# Altium schematic sheet MCU.SchDoc(MCU)
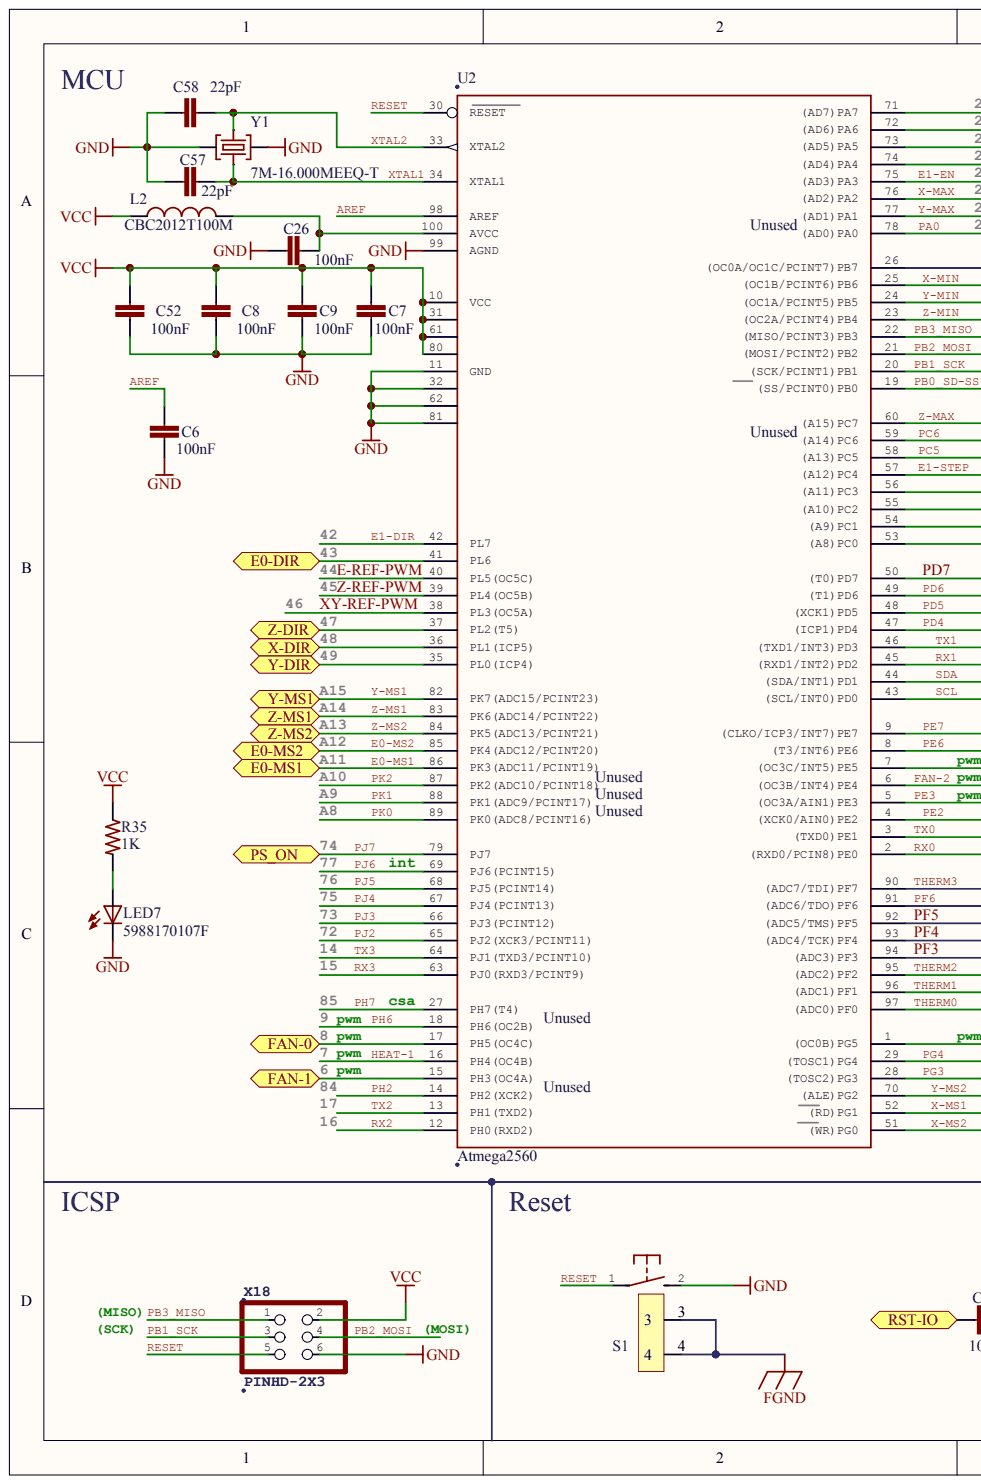
[diagram p0: MCU.SchDoc, left]
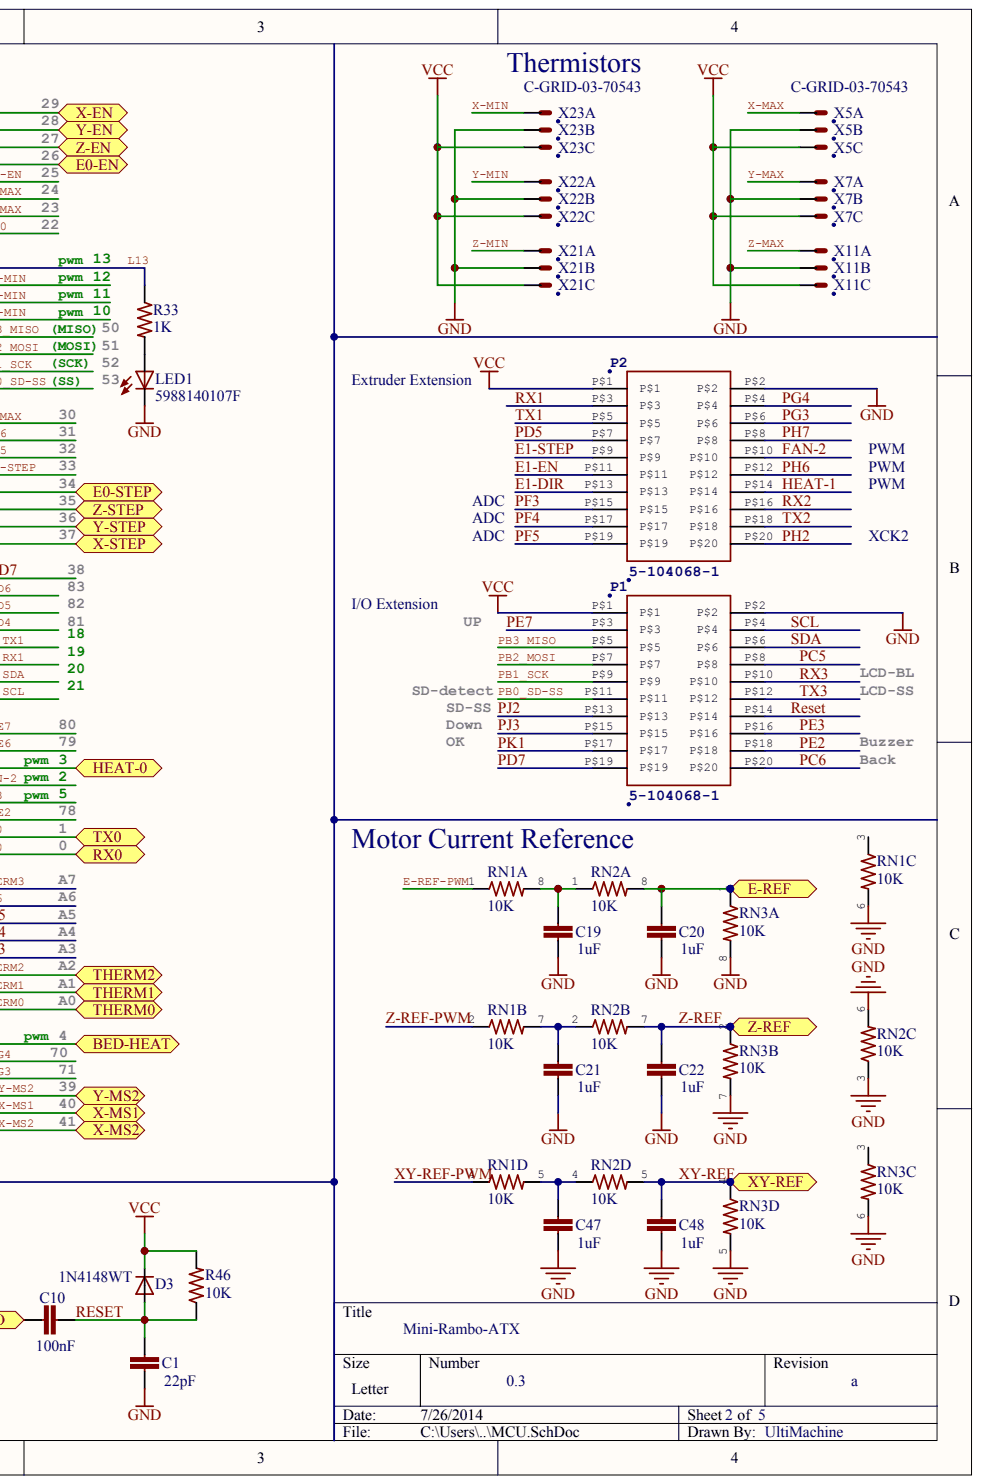
[diagram p1: MCU.SchDoc, right]

## Parts
C1  22pF
C6  100nF
C7  100nF
C8  100nF
C9  100nF
C10  100nF
C19  1uF
C20  1uF
C21  1uF
C22  1uF
C26  100nF
C47  1uF
C48  1uF
C52  100nF
C57  22pF
C58  22pF
D3  1N4148WT
L2  CBC2012T100M
LED1  5988140107F
LED7  5988170107F
P1  5-104068-1
P2  5-104068-1
R33  1K
R35  1K
R46  10K
RN1  10K  (parts A,B,C,D)
RN2  10K  (parts A,B,C,D)
RN3  10K  (parts A,B,C,D)
S1  1571610-2  (value not drawn)
U2  Atmega2560
X5  C-GRID-03-70543  (parts A,B,C)
X7  C-GRID-03-70543  (parts A,B,C)
X11  C-GRID-03-70543  (parts A,B,C)
X18  PINHD-2X3
X21  C-GRID-03-70543  (parts A,B,C)
X22  C-GRID-03-70543  (parts A,B,C)
X23  C-GRID-03-70543  (parts A,B,C)
Y1  7M-16.000MEEQ-T

## Ports
BED-HEAT, E0-DIR, E0-EN, E0-MS1, E0-MS2, E0-STEP, E-REF, FAN-0, FAN-1, HEAT-0, PS_ON, RST-IO, RX0, THERM0, THERM1, THERM2, TX0, X-DIR, X-EN, X-MS1, X-MS2, X-STEP, XY-REF, Y-DIR, Y-EN, Y-MS1, Y-MS2, Y-STEP, Z-DIR, Z-EN, Z-MS1, Z-MS2, Z-REF, Z-STEP

## Nets
FGND: S1.3 S1.4
GND: C1.2 C6.2 C7.2 C8.2 C9.2 C19.2 C20.2 C21.2 C22.2 C26.2 C47.2 C48.2 C52.2 C57.2 C58.2 LED1.C LED7.C P1.P$2 P2.P$2 RN1.6 RN2.3 RN2.6 RN3.5 RN3.6 RN3.7 RN3.8 S1.2 U2.11(GND) U2.32 U2.62 U2.81 U2.99(AGND) X5.2 X7.2 X11.2 X18.6 X21.2 X22.2 X23.2 Y1.2 Y1.4
VCC: C7.1 C8.1 C9.1 C52.1 D3.C L2.1 P1.P$1 P2.P$1 R35.1 R46.2 U2.10(VCC) U2.31 U2.61 U2.80 X5.3 X7.3 X11.3 X18.2 X21.3 X22.3 X23.3
AREF: C6.1 U2.98(AREF)
BED-HEAT: U2.1((OC0B)PG5) ; port BED-HEAT
E0-DIR: U2.41(PL6) ; port E0-DIR
E0-EN: U2.74((AD4)PA4) ; port E0-EN
E0-MS1: U2.86(PK3(ADC11/PCINT19)) ; port E0-MS1
E0-MS2: U2.85(PK4(ADC12/PCINT20)) ; port E0-MS2
E0-STEP: U2.56((A11)PC3) ; port E0-STEP
E1-DIR: P2.P$13 U2.42(PL7)
E1-EN: P2.P$11 U2.75((AD3)PA3)
E1-STEP: P2.P$9 U2.57((A12)PC4)
E-REF: C20.1 RN2.8 RN3.1 ; port E-REF
E-REF-PWM: RN1.1 U2.40(PL5(OC5C))
FAN-0: U2.17(PH5(OC4C)) ; port FAN-0
FAN-1: U2.15(PH3(OC4A)) ; port FAN-1
FAN-2: P2.P$10 U2.6((OC3B/INT4)PE4)
HEAT-0: U2.7((OC3C/INT5)PE5) ; port HEAT-0
HEAT-1: P2.P$14 U2.16(PH4(OC4B))
L13: R33.1 U2.26((OC0A/OC1C/PCINT7)PB7)
PA0: U2.78((AD0)PA0)
PB0_SD-SS: P1.P$11 U2.19((SS/PCINT0)PB0)
PB1_SCK: P1.P$9 U2.20((SCK/PCINT1)PB1) X18.3
PB2_MOSI: P1.P$7 U2.21((MOSI/PCINT2)PB2) X18.4
PB3_MISO: P1.P$5 U2.22((MISO/PCINT3)PB3) X18.1
PC5: P1.P$8 U2.58((A13)PC5)
PC6: P1.P$20 U2.59((A14)PC6)
PD4: U2.47((ICP1)PD4)
PD5: P2.P$7 U2.48((XCK1)PD5)
PD6: U2.49((T1)PD6)
PD7: P1.P$19 U2.50((T0)PD7)
PE2: P1.P$18 U2.4((XCK0/AIN0)PE2)
PE3: P1.P$16 U2.5((OC3A/AIN1)PE3)
PE6: U2.8((T3/INT6)PE6)
PE7: P1.P$3 U2.9((CLKO/ICP3/INT7)PE7)
PF3: P2.P$15 U2.94((ADC3)PF3)
PF4: P2.P$17 U2.93((ADC4/TCK)PF4)
PF5: P2.P$19 U2.92((ADC5/TMS)PF5)
PF6: U2.91((ADC6/TDO)PF6)
PG3: P2.P$6 U2.28((TOSC2)PG3)
PG4: P2.P$4 U2.29((TOSC1)PG4)
PH2: P2.P$20 U2.14(PH2(XCK2))
PH6: P2.P$12 U2.18(PH6(OC2B))
PH7: P2.P$8 U2.27(PH7(T4))
PJ2: P1.P$13 U2.65(PJ2(XCK3/PCINT11))
PJ3: P1.P$15 U2.66(PJ3(PCINT12))
PJ4: U2.67(PJ4(PCINT13))
PJ5: U2.68(PJ5(PCINT14))
PJ6: U2.69(PJ6(PCINT15))
PJ7: U2.79(PJ7) ; port PS_ON
PK0: U2.89(PK0(ADC8/PCINT16))
PK1: P1.P$17 U2.88(PK1(ADC9/PCINT17))
PK2: U2.87(PK2(ADC10/PCINT18))
RESET: C1.1 C10.2 D3.A P1.P$14 R46.1 S1.1 U2.30(RESET) X18.5
RST-IO: C10.1 ; port RST-IO
RX0: U2.2((RXD0/PCIN8)PE0) ; port RX0
RX1: P2.P$3 U2.45((RXD1/INT2)PD2)
RX2: P2.P$16 U2.12(PH0(RXD2))
RX3: P1.P$10 U2.63(PJ0(RXD3/PCINT9))
SCL: P1.P$4 U2.43((SCL/INT0)PD0)
SDA: P1.P$6 U2.44((SDA/INT1)PD1)
THERM0: U2.97((ADC0)PF0) ; port THERM0
THERM1: U2.96((ADC1)PF1) ; port THERM1
THERM2: U2.95((ADC2)PF2) ; port THERM2
THERM3: U2.90((ADC7/TDI)PF7)
TX0: U2.3((TXD0)PE1) ; port TX0
TX1: P2.P$5 U2.46((TXD1/INT3)PD3)
TX2: P2.P$18 U2.13(PH1(TXD2))
TX3: P1.P$12 U2.64(PJ1(TXD3/PCINT10))
X-DIR: U2.36(PL1(ICP5)) ; port X-DIR
X-EN: U2.71((AD7)PA7) ; port X-EN
X-MAX: U2.76((AD2)PA2) X5.1
X-MIN: U2.25((OC1B/PCINT6)PB6) X23.1
X-MS1: U2.52((RD)PG1) ; port X-MS1
X-MS2: U2.51((WR)PG0) ; port X-MS2
X-STEP: U2.53((A8)PC0) ; port X-STEP
XTAL1: C57.1 U2.34(XTAL1) Y1.1
XTAL2: C58.1 U2.33(XTAL2) Y1.3
XY-REF: C48.1 RN2.5 RN3.4 ; port XY-REF
XY-REF-PWM: RN1.4 U2.38(PL3(OC5A))
Y-DIR: U2.35(PL0(ICP4)) ; port Y-DIR
Y-EN: U2.72((AD6)PA6) ; port Y-EN
Y-MAX: U2.77((AD1)PA1) X7.1
Y-MIN: U2.24((OC1A/PCINT5)PB5) X22.1
Y-MS1: U2.82(PK7(ADC15/PCINT23)) ; port Y-MS1
Y-MS2: U2.70((ALE)PG2) ; port Y-MS2
Y-STEP: U2.54((A9)PC1) ; port Y-STEP
Z-DIR: U2.37(PL2(T5)) ; port Z-DIR
Z-EN: U2.73((AD5)PA5) ; port Z-EN
Z-MAX: U2.60((A15)PC7) X11.1
Z-MIN: U2.23((OC2A/PCINT4)PB4) X21.1
Z-MS1: U2.83(PK6(ADC14/PCINT22)) ; port Z-MS1
Z-MS2: U2.84(PK5(ADC13/PCINT21)) ; port Z-MS2
Z-REF: C22.1 RN2.7 RN3.2 ; port Z-REF
Z-REF-PWM: RN1.2 U2.39(PL4(OC5B))
Z-STEP: U2.55((A10)PC2) ; port Z-STEP
NetC19_1: C19.1 RN1.8 RN2.1
NetC21_1: C21.1 RN1.7 RN2.2
NetC26_1: C26.1 L2.2 U2.100(AVCC)
NetC47_1: C47.1 RN1.5 RN2.4
NetLED1_A: LED1.A R33.2
NetLED7_A: LED7.A R35.2
N00068:

Not connected: RN1 3; RN3 3

## Library data of the parts
C1 C57 C58 = (no symbol name): ManufacturerPartNumber: 04025A220JAT2A; LastRevisionNote: ImportComponents; Published: 23-Apr-2014; LastRevisionDate: 23-Apr-2014; VALUE: 22pF; Publisher: UltiMachine
C6-C10 C26 C52 = (no symbol name): ManufacturerPartNumber: GRM155R71C104KA88D; LastRevisionNote: ImportComponents; Published: 23-Apr-2014; LastRevisionDate: 23-Apr-2014; VALUE: 100nF; Publisher: UltiMachine
C19-C22 C47 C48 = (no symbol name): ManufacturerPartNumber: GRM155R60J105KE19D; LastRevisionNote: ImportComponents; Published: 23-Apr-2014; LastRevisionDate: 23-Apr-2014; VALUE: 1uF; Publisher: UltiMachine
D3 L2 LED1 LED7 U2 X5 X7 X11 X18 X21-X23 Y1 = (no symbol name): LastRevisionNote: ImportComponents; Published: 23-Apr-2014; LastRevisionDate: 23-Apr-2014; Publisher: UltiMachine
  D3: Value: 1N4148WT
  L2: ManufacturerPartNumber: CBC2012T100M; Value: CBC2012T100M
  LED1: VALUE: 5988140107F
  LED7: VALUE: 5988170107F
  U2: Supplier 1: Digi-Key; Supplier Part Number 1: ATMEGA2560-16AURTR-ND
  Y1: ManufacturerPartNumber: 7M-16.000MEEQ-T
P1 P2 = (no symbol name): ManufacturerPartNumber: RSB39VTE-17; LastRevisionNote: ImportComponents; Published: 23-Apr-2014; LastRevisionDate: 23-Apr-2014; Publisher: UltiMachine
R33 R35 = (no symbol name): ManufacturerPartNumber: ERJ-3EKF1001V; LastRevisionNote: ImportComponents; Published: 23-Apr-2014; LastRevisionDate: 23-Apr-2014; VALUE: 1K; Publisher: UltiMachine
R46 = (no symbol name): ManufacturerPartNumber: ERJ-3EKF1002V; LastRevisionNote: ImportComponents; Published: 23-Apr-2014; LastRevisionDate: 23-Apr-2014; VALUE: 10K; Publisher: UltiMachine
RN1-RN3 = (no symbol name): Manufacturer: Bourns; ManufacturerPartNumber: CAY16-103J4LF; LastRevisionNote: ImportComponents; ComponentLink1URL: http://www.bourns.com/data/global/pdfs/CATCAY.pdf; ComponentLink1Description: Datasheet for CAY16; Published: 23-Apr-2014; LastRevisionDate: 23-Apr-2014; VALUE: 10K; Publisher: UltiMachine
S1 = (no symbol name): Manufacturer: TE; LastRevisionNote: Import; ComponentLink1URL: http://www.te.com/commerce/DocumentDelivery/DDEController?Action=srchrtrv&DocNm=1571610&DocType=Customer+Drawing&DocLang=English; ComponentLink1Description: Datasheet for B3F-31XX; Published: 23-Apr-2014; LastRevisionDate: 23-Apr-2014; ManufacturerPartNumber: 1571610-2; Supplier 1: Mouser; Supplier Part Number 1: 506-1571610-2; Publisher: UltiMachine
